# Altium schematic sheet COMMUNICATION.SchDoc
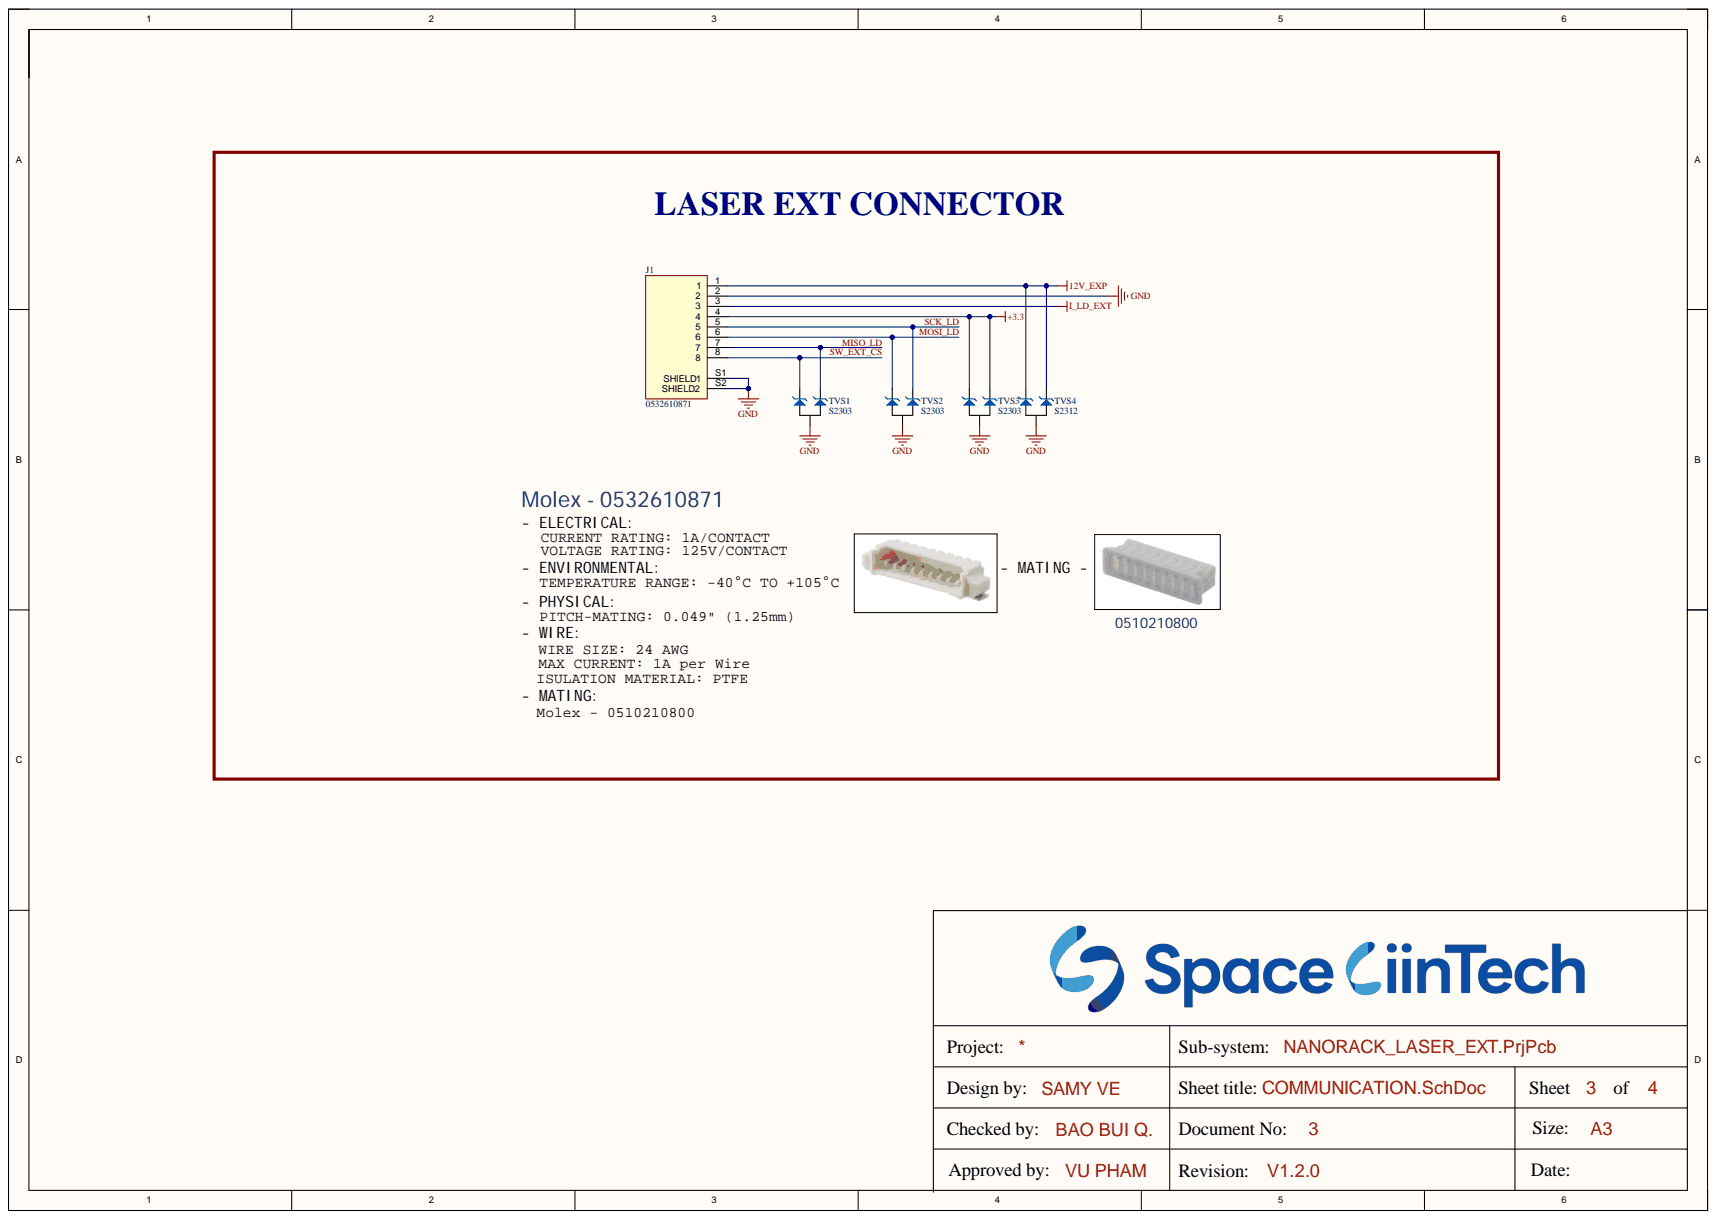
[diagram p0: COMMUNICATION.SchDoc]

## Parts
J1  0532610871  [0532610871]
TVS1  S2303  [SOT23-123]
TVS2  S2303  [SOT23-123]
TVS3  S2303  [SOT23-123]
TVS4  S2312  [SOT23-123]

## Nets
12V_EXP: J1.1 TVS4.1 TVS4.3
+3.3: J1.4 TVS3.1 TVS3.3
GND: J1.2 J1.S1(SHIELD1) J1.S2(SHIELD2) TVS1.2 TVS2.2 TVS3.2 TVS4.2
I_LD_EXT: J1.3
MISO_LD: J1.7 TVS1.1
MOSI_LD: J1.6 TVS2.3
SCK_LD: J1.5 TVS2.1
SW_EXT_CS: J1.8 TVS1.3

## Library data of the parts
J1 = Header8 - Shield: CONN HEADER SMD R/A 8POS 1.25MM; Component Kind: Standard; Library Name: Library.SchLib
TVS1-TVS3 = S2303: TVS DIODE 3.3VWM 8VC SOT233; Component Kind: Standard; Library Name: Library.SchLib
TVS4 = S2315: TVS DIODE 12VWM 19VC SOT233; Component Kind: Standard; Library Name: Library.SchLib
